# Altium schematic sheet 1.8-TFT-128x160.SchDoc(1.8-TFT-128x160)
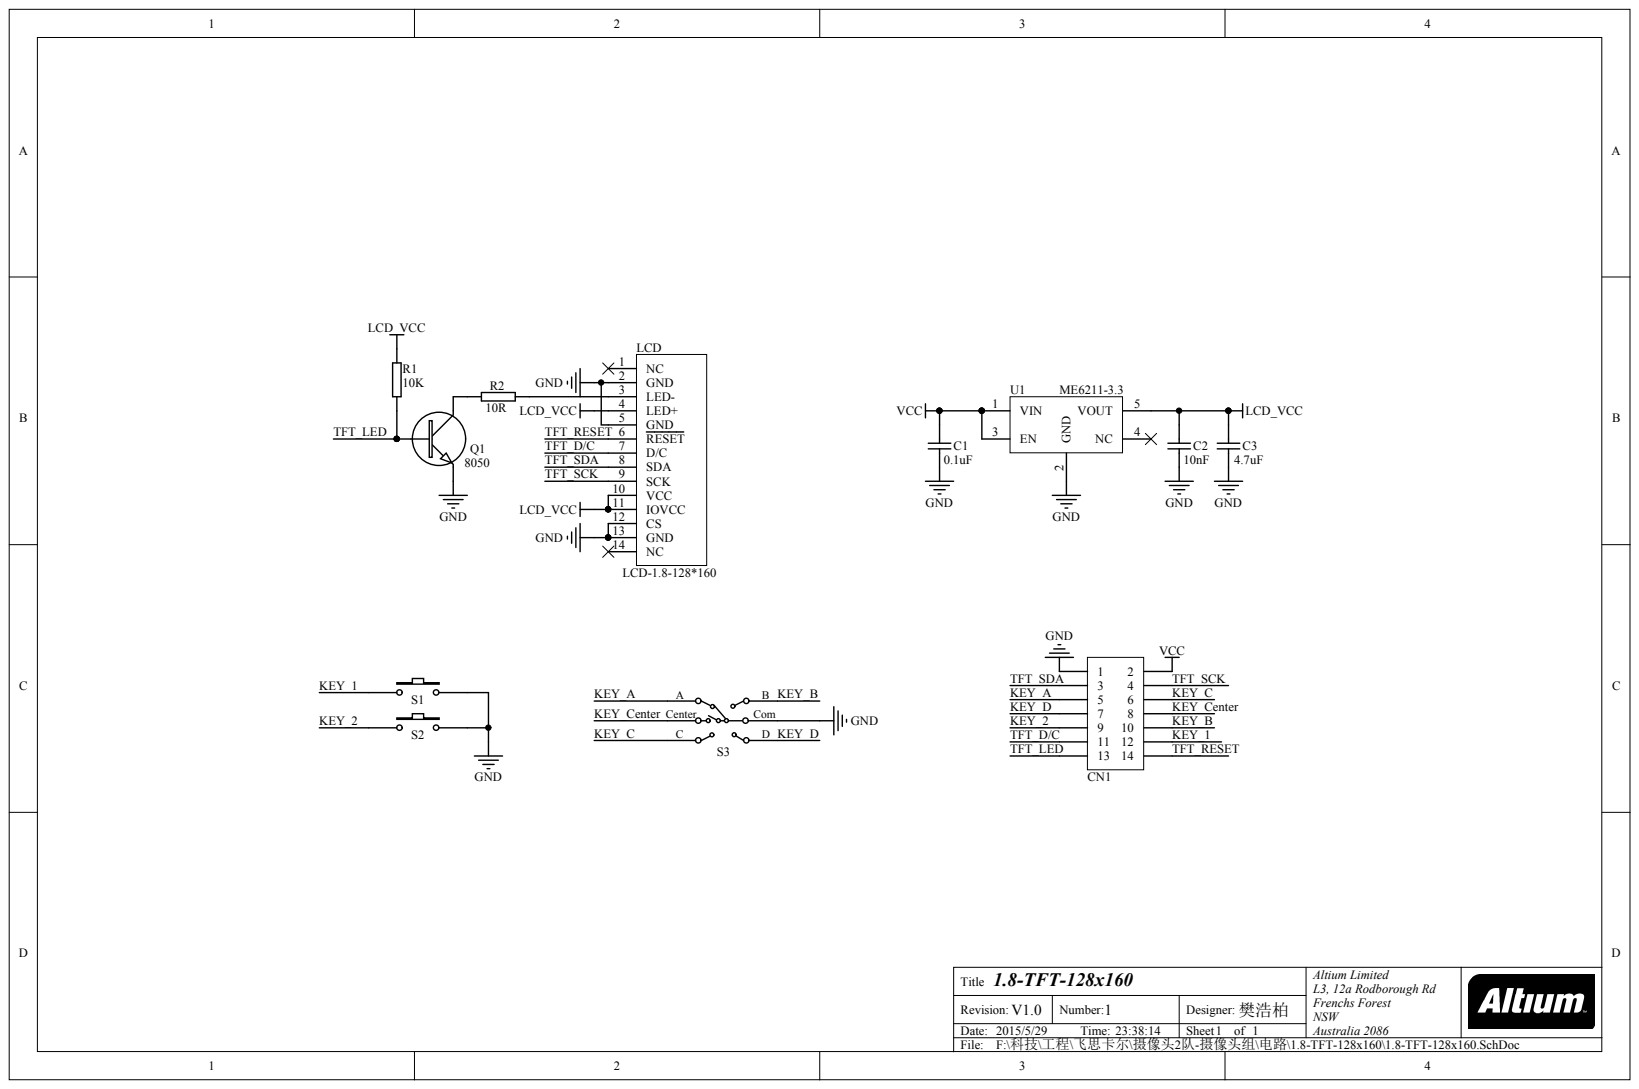
[diagram p0: 1.8-TFT-128x160.SchDoc]

## Parts
C1  0.1uF
C2  10nF
C3  4.7uF
CN1  CON-7X2  (value not drawn)
LCD  LCD-1.8-128*160
Q1  8050
R1  10K
R2  10R
S1  Key  (value not drawn)
S2  Key  (value not drawn)
S3  Switch  (value not drawn)
U1  ME6211-3.3

## Nets
GND: C1.1 C2.1 C3.1 CN1.1 LCD.2(GND) LCD.5(GND) LCD.12(CS) LCD.13(GND) Q1.2 S1.2 S2.2 S3.5 U1.2(GND)
LCD_VCC: C2.2 C3.2 LCD.4(LED+) LCD.10(VCC) LCD.11(IOVCC) R1.2 U1.5(VOUT)
VCC: C1.2 CN1.2 U1.1(VIN) U1.3(EN)
KEY_1: CN1.12 S1.1
KEY_2: CN1.9 S2.1
KEY_A: CN1.5 S3.1
KEY_B: CN1.10 S3.4
KEY_C: CN1.6 S3.3
KEY_CENTER: CN1.8 S3.2
KEY_D: CN1.7 S3.6
TFT_D/C: CN1.11 LCD.7(D/C)
TFT_LED: CN1.13 Q1.1 R1.1
TFT_RESET: CN1.14 LCD.6(RESET)
TFT_SCK: CN1.4 LCD.9(SCK)
TFT_SDA: CN1.3 LCD.8(SDA)
NetLCD_3: LCD.3(LED-) R2.2
NetQ1_3: Q1.3 R2.1

Not connected: LCD 1(NC) 14(NC); U1 4(NC)

## Library data of the parts
C1-C3 CN1 LCD Q1 R1 R2 S1-S3 U1 = (no symbol name)
  U1: Published: 24-Mar-1999; LatestRevisionDate: 29-May-2009; LatestRevisionNote: IPC-7351 Footprint Added.; PackageReference: D2PAK; PackageDescription: 3-Pin Transistor Outline Package 2.54 mm Pitch, 15.1 mm Lead Span; Publisher: Altium Limited
